# Altium schematic sheet S32K144EVB.SchDoc(S32K144EVB)
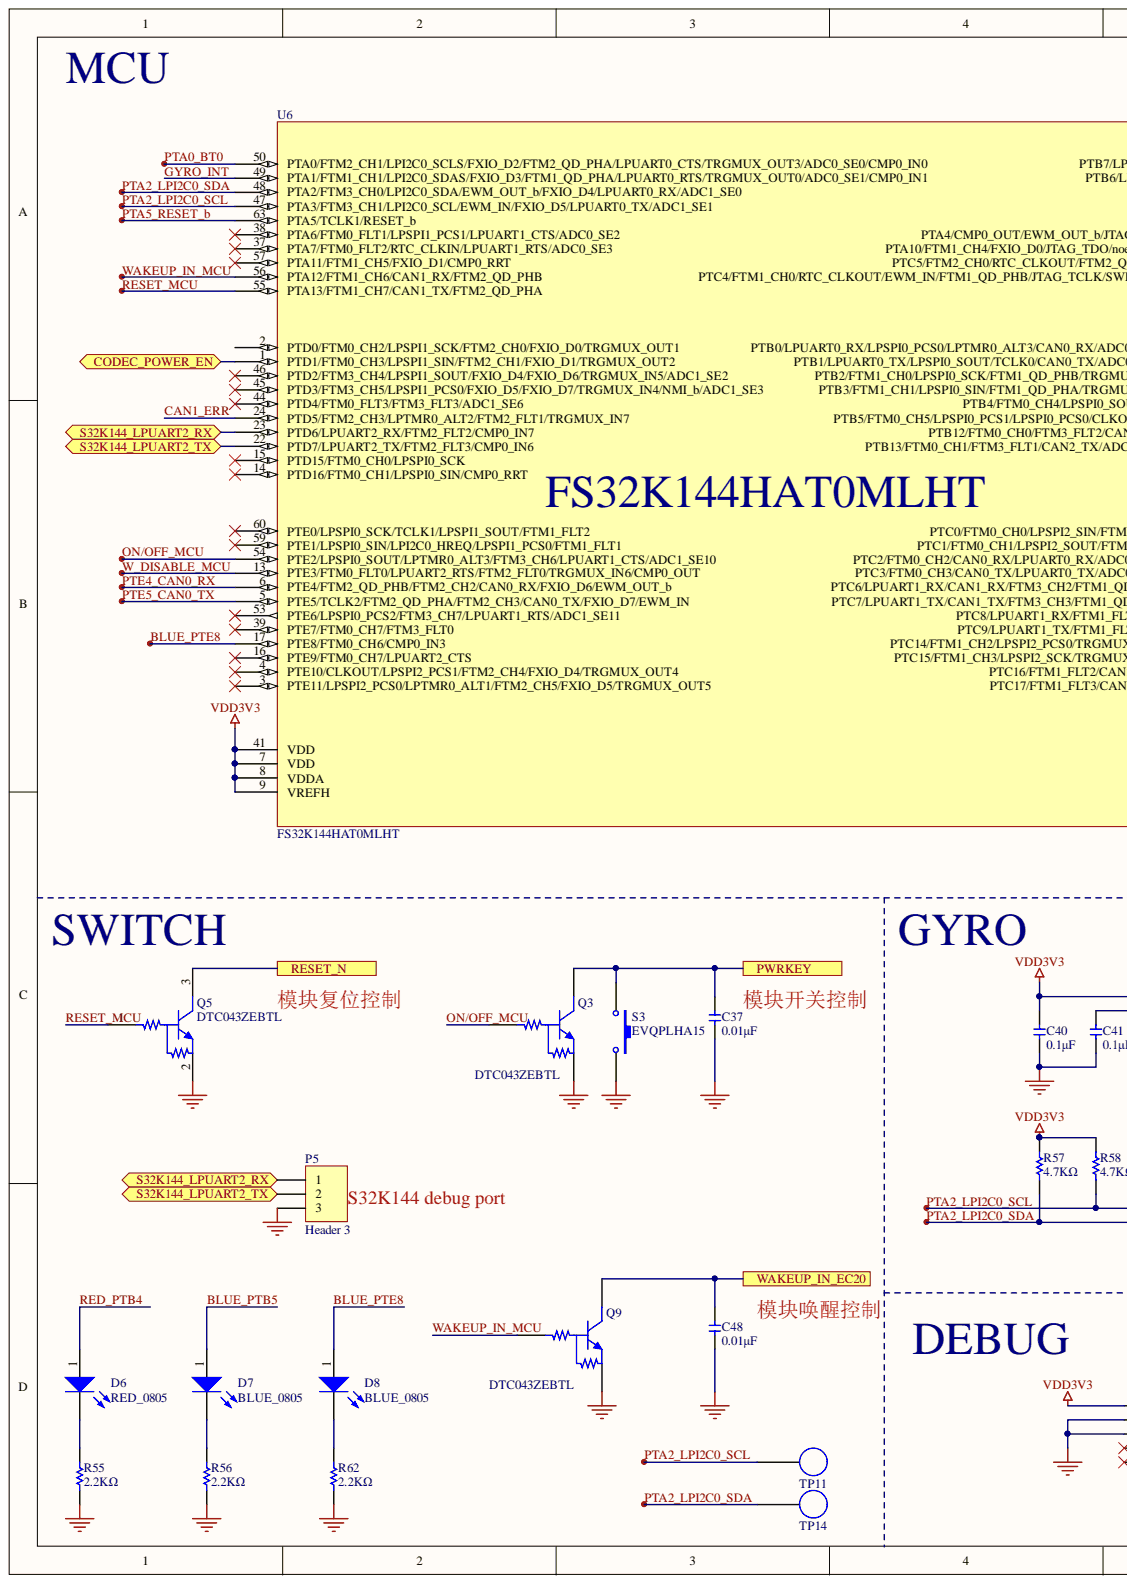
[diagram p0: S32K144EVB.SchDoc, left]
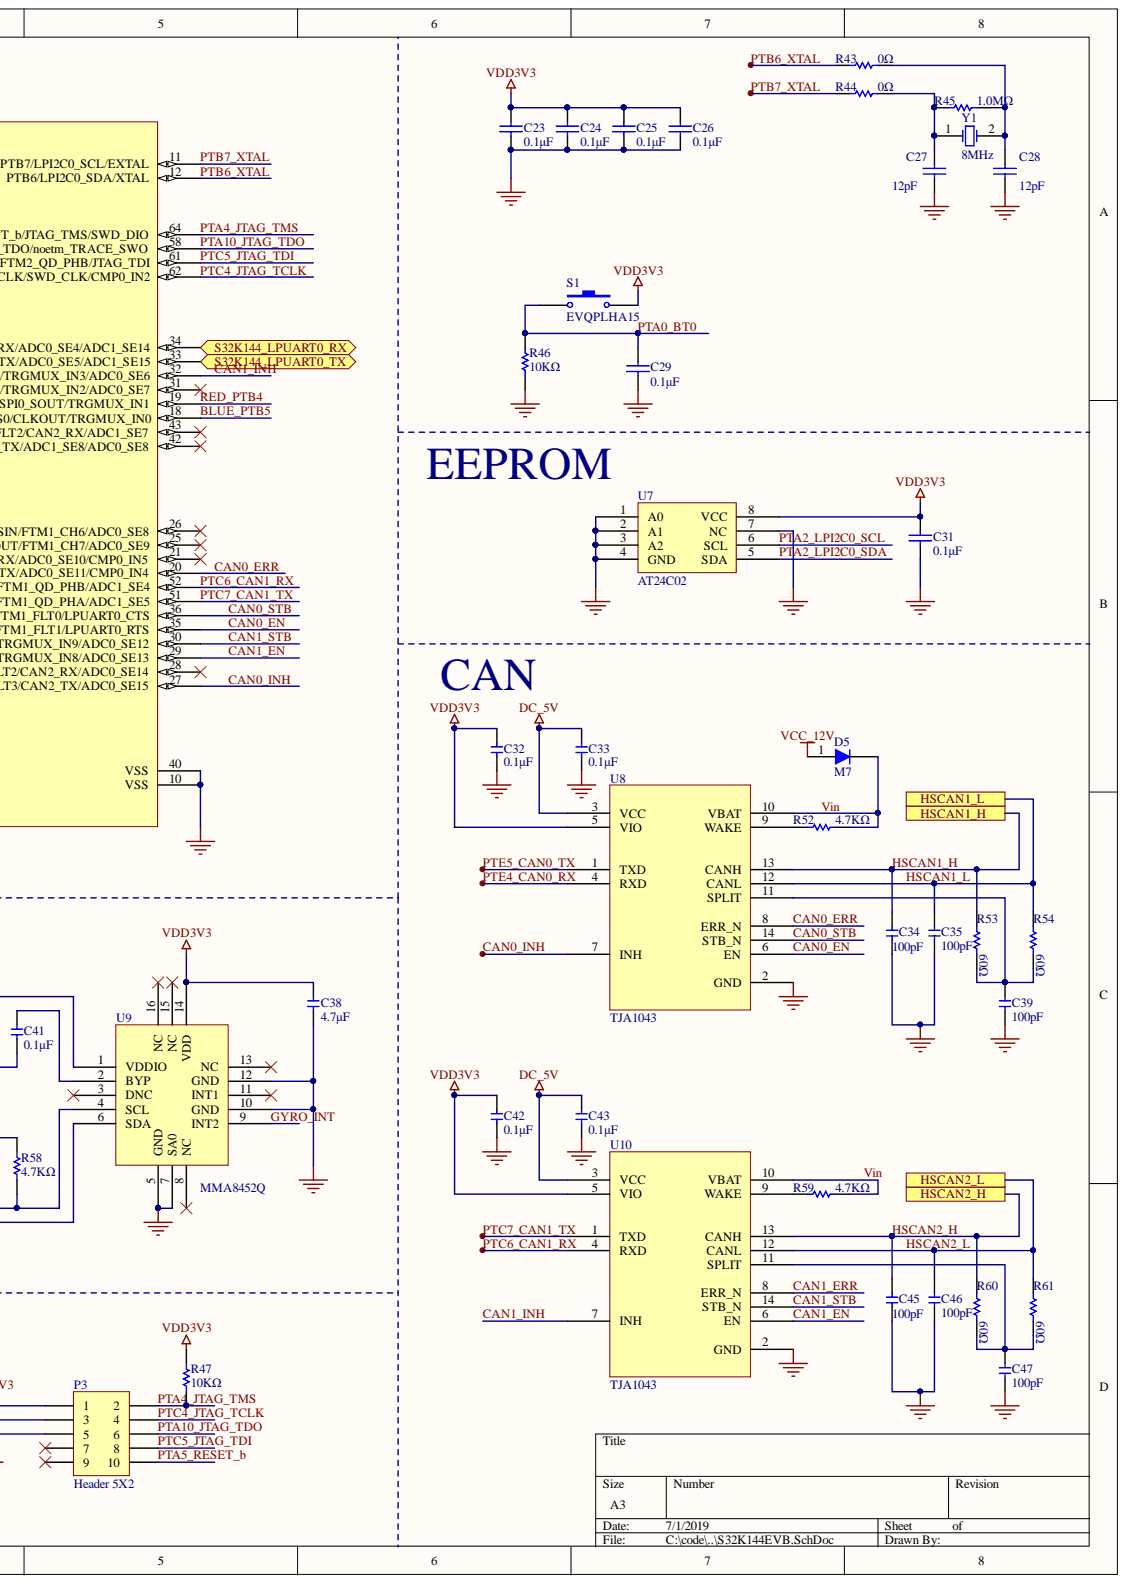
[diagram p1: S32K144EVB.SchDoc, right]

## Parts
C23  0.1μF  [C0402]
C24  0.1μF  [C0402]
C25  0.1μF  [C0402]
C26  0.1μF  [C0402]
C27  12pF  [C0402]
C28  12pF  [C0402]
C29  0.1μF  [C0402]
C31  0.1μF  [C0402]
C32  0.1μF  [C0402]
C33  0.1μF  [C0402]
C34  100pF  [C0402]
C35  100pF  [C0402]
C37  0.01μF  [C0402]
C38  4.7μF  [C0402]
C39  100pF  [C0402]
C40  0.1μF  [C0402]
C41  0.1μF  [C0402]
C42  0.1μF  [C0402]
C43  0.1μF  [C0402]
C45  100pF  [C0402]
C46  100pF  [C0402]
C47  100pF  [C0402]
C48  0.01μF  [C0402]
D5  M7  [SMA]
D6  RED_0805  [LED_0805]
D7  BLUE_0805  [LED_0805]
D8  BLUE_0805  [LED_0805]
P3  Header 5X2  [HDR5X2_127]
P5  Header 3  [HDR1X3]
Q3  DTC043ZEBTL  [SOT723]
Q5  DTC043ZEBTL  [SOT723]
Q9  DTC043ZEBTL  [SOT723]
R43  0Ω  [R0402]
R44  0Ω  [R0402]
R45  1.0MΩ  [R0402]
R46  10KΩ  [R0402]
R47  10KΩ  [R0402]
R52  4.7KΩ  [R0402]
R53  60Ω  [R0402]
R54  60Ω  [R0402]
R55  2.2KΩ  [R0402]
R56  2.2KΩ  [R0402]
R57  4.7KΩ  [R0402]
R58  4.7KΩ  [R0402]
R59  4.7KΩ  [R0402]
R60  60Ω  [R0402]
R61  60Ω  [R0402]
R62  2.2KΩ  [R0402]
S1  EVQPLHA15  [SW-DPST]
S3  EVQPLHA15  [SW-DPST]
TP11  TestPoint  [TP]  (value not drawn)
TP14  TestPoint  [TP]  (value not drawn)
U6  FS32K144HAT0MLHT  [LQFP-64]
U7  AT24C02  [SOIC-8_N]
U8  TJA1043  [SOIC-14 - 6MM]
U9  MMA8452Q  [QFN16]
U10  TJA1043  [SOIC-14 - 6MM]
Y1  8MHz  [XT5032]

## Ports
CODEC_POWER_EN, HSCAN1_H, HSCAN1_L, HSCAN2_H, HSCAN2_L, PWRKEY, RESET_N, S32K144_LPUART0_RX, S32K144_LPUART0_TX, S32K144_LPUART2_RX, S32K144_LPUART2_TX, WAKEUP_IN_EC20

## Nets
DC_5V: C33.2 C43.2 U8.3(VCC) U10.3(VCC)
GND: C23.1 C24.1 C25.1 C26.1 C27.1 C28.2 C29.1 C31.1 C32.1 C33.1 C34.1 C35.1 C37.1 C38.1 C39.1 C40.1 C41.1 C42.1 C43.1 C45.1 C46.1 C47.1 C48.1 P3.3 P3.5 P5.3 Q3.2 Q5.2 Q9.2 R46.2 R55.2 R56.2 R62.2 S3.2 U6.10(VSS) U6.40(VSS) U7.1(A0) U7.2(A1) U7.3(A2) U7.4(GND) U7.7(NC) U8.2(GND) U9.5(GND) U9.7(SA0) U9.10(GND) U9.12(GND) U10.2(GND)
VCC_12V: D5.1
VDD3V3: C23.2 C24.2 C25.2 C26.2 C31.2 C32.2 C38.2 C40.2 C42.2 P3.1 R47.1 R57.1 R58.1 S1.2 U6.7(VDD) U6.8(VDDA) U6.9(VREFH) U6.41(VDD) U7.8(VCC) U8.5(VIO) U9.1(VDDIO) U9.14(VDD) U10.5(VIO)
BLUE_PTB5: D7.1 U6.18(PTB5/FTM0_CH5/LPSPI0_PCS1/LPSPI0_PCS0/CLKOUT/TRGMUX_IN0)
BLUE_PTE8: D8.1 U6.17(PTE8/FTM0_CH6/CMP0_IN3)
CAN0_EN: U6.35(PTC9/LPUART1_TX/FTM1_FLT1/LPUART0_RTS) U8.6(EN)
CAN0_ERR: U6.20(PTC3/FTM0_CH3/CAN0_TX/LPUART0_TX/ADC0_SE11/CMP0_IN4) U8.8(ERR_N)
CAN0_INH: U6.27(PTC17/FTM1_FLT3/CAN2_TX/ADC0_SE15) U8.7(INH)
CAN0_STB: U6.36(PTC8/LPUART1_RX/FTM1_FLT0/LPUART0_CTS) U8.14(STB_N)
CAN1_EN: U6.29(PTC15/FTM1_CH3/LPSPI2_SCK/TRGMUX_IN8/ADC0_SE13) U10.6(EN)
CAN1_ERR: U6.24(PTD5/FTM2_CH3/LPTMR0_ALT2/FTM2_FLT1/TRGMUX_IN7) U10.8(ERR_N)
CAN1_INH: U6.32(PTB2/FTM1_CH0/LPSPI0_SCK/FTM1_QD_PHB/TRGMUX_IN3/ADC0_SE6) U10.7(INH)
CAN1_STB: U6.30(PTC14/FTM1_CH2/LPSPI2_PCS0/TRGMUX_IN9/ADC0_SE12) U10.14(STB_N)
CODEC_POWER_EN: U6.1(PTD1/FTM0_CH3/LPSPI1_SIN/FTM2_CH1/FXIO_D1/TRGMUX_OUT2) ; port CODEC_POWER_EN
GYRO_INT: U6.49(PTA1/FTM1_CH1/LPI2C0_SDAS/FXIO_D3/FTM1_QD_PHA/LPUART0_RTS/TRGMUX_OUT0/ADC0_SE1/CMP0_IN1) U9.9(INT2)
HSCAN1_H: C34.2 R53.2 U8.13(CANH) ; port HSCAN1_H
HSCAN1_L: C35.2 R54.2 U8.12(CANL) ; port HSCAN1_L
HSCAN2_H: C45.2 R60.2 U10.13(CANH) ; port HSCAN2_H
HSCAN2_L: C46.2 R61.2 U10.12(CANL) ; port HSCAN2_L
ON/OFF_MCU: Q3.1 U6.54(PTE2/LPSPI0_SOUT/LPTMR0_ALT3/FTM3_CH6/LPUART1_CTS/ADC1_SE10)
PTA0_BT0: C29.2 R46.1 S1.1 U6.50(PTA0/FTM2_CH1/LPI2C0_SCLS/FXIO_D2/FTM2_QD_PHA/LPUART0_CTS/TRGMUX_OUT3/ADC0_SE0/CMP0_IN0)
PTA2_LPI2C0_SCL: R58.2 TP11.1 U6.47(PTA3/FTM3_CH1/LPI2C0_SCL/EWM_IN/FXIO_D5/LPUART0_TX/ADC1_SE1) U7.6(SCL) U9.4(SCL)
PTA2_LPI2C0_SDA: R57.2 TP14.1 U6.48(PTA2/FTM3_CH0/LPI2C0_SDA/EWM_OUT_b/FXIO_D4/LPUART0_RX/ADC1_SE0) U7.5(SDA) U9.6(SDA)
PTA4_JTAG_TMS: P3.2 R47.2 U6.64(PTA4/CMP0_OUT/EWM_OUT_b/JTAG_TMS/SWD_DIO)
PTA5_RESET_b: P3.10 U6.63(PTA5/TCLK1/RESET_b)
PTA10_JTAG_TDO: P3.6 U6.58(PTA10/FTM1_CH4/FXIO_D0/JTAG_TDO/noetm_TRACE_SWO)
PTB6_XTAL: R43.2 U6.12(PTB6/LPI2C0_SDA/XTAL)
PTB7_XTAL: R44.2 U6.11(PTB7/LPI2C0_SCL/EXTAL)
PTC4_JTAG_TCLK: P3.4 U6.62(PTC4/FTM1_CH0/RTC_CLKOUT/EWM_IN/FTM1_QD_PHB/JTAG_TCLK/SWD_CLK/CMP0_IN2)
PTC5_JTAG_TDI: P3.8 U6.61(PTC5/FTM2_CH0/RTC_CLKOUT/FTM2_QD_PHB/JTAG_TDI)
PTC6_CAN1_RX: U6.52(PTC6/LPUART1_RX/CAN1_RX/FTM3_CH2/FTM1_QD_PHB/ADC1_SE4) U10.4(RXD)
PTC7_CAN1_TX: U6.51(PTC7/LPUART1_TX/CAN1_TX/FTM3_CH3/FTM1_QD_PHA/ADC1_SE5) U10.1(TXD)
PTE4_CAN0_RX: U6.6(PTE4/FTM2_QD_PHB/FTM2_CH2/CAN0_RX/FXIO_D6/EWM_OUT_b) U8.4(RXD)
PTE5_CAN0_TX: U6.5(PTE5/TCLK2/FTM2_QD_PHA/FTM2_CH3/CAN0_TX/FXIO_D7/EWM_IN) U8.1(TXD)
PWRKEY: C37.2 Q3.3 S3.1 ; port PWRKEY
RED_PTB4: D6.1 U6.19(PTB4/FTM0_CH4/LPSPI0_SOUT/TRGMUX_IN1)
RESET_MCU: Q5.1 U6.55(PTA13/FTM1_CH7/CAN1_TX/FTM2_QD_PHA)
RESET_N: Q5.3 ; port RESET_N
S32K144_LPUART0_RX: U6.34(PTB0/LPUART0_RX/LPSPI0_PCS0/LPTMR0_ALT3/CAN0_RX/ADC0_SE4/ADC1_SE14) ; port S32K144_LPUART0_RX
S32K144_LPUART0_TX: U6.33(PTB1/LPUART0_TX/LPSPI0_SOUT/TCLK0/CAN0_TX/ADC0_SE5/ADC1_SE15) ; port S32K144_LPUART0_TX
S32K144_LPUART2_RX: P5.1 U6.23(PTD6/LPUART2_RX/FTM2_FLT2/CMP0_IN7) ; port S32K144_LPUART2_RX
S32K144_LPUART2_TX: P5.2 U6.22(PTD7/LPUART2_TX/FTM2_FLT3/CMP0_IN6) ; port S32K144_LPUART2_TX
Vin: D5.2 R52.2 R59.2 U8.10(VBAT) U10.10(VBAT)
W_DISABLE_MCU: U6.13(PTE3/FTM0_FLT0/LPUART2_RTS/FTM2_FLT0/TRGMUX_IN6/CMP0_OUT)
WAKEUP_IN_EC20: C48.2 Q9.3 ; port WAKEUP_IN_EC20
WAKEUP_IN_MCU: Q9.1 U6.56(PTA12/FTM1_CH6/CAN1_RX/FTM2_QD_PHB)
NetC27_2: C27.2 R44.1 R45.2 Y1.1
NetC28_1: C28.1 R43.1 R45.1 Y1.2
NetC39_2: C39.2 R53.1 R54.1 U8.11(SPLIT)
NetC41_2: C41.2 U9.2(BYP)
NetC47_2: C47.2 R60.1 R61.1 U10.11(SPLIT)
NetD6_2: D6.2 R55.1
NetD7_2: D7.2 R56.1
NetD8_2: D8.2 R62.1
NetR52_1: R52.1 U8.9(WAKE)
NetR59_1: R59.1 U10.9(WAKE)

Not connected: P3 7 9; U6 2(PTD0/FTM0_CH2/LPSPI1_SCK/FTM2_CH0/FXIO_D0/TRGMUX_OUT1) 3(PTE11/LPSPI2_PCS0/LPTMR0_ALT1/FTM2_CH5/FXIO_D5/TRGMUX_OUT5) 4(PTE10/CLKOUT/LPSPI2_PCS1/FTM2_CH4/FXIO_D4/TRGMUX_OUT4) 14(PTD16/FTM0_CH1/LPSPI0_SIN/CMP0_RRT) 15(PTD15/FTM0_CH0/LPSPI0_SCK) 16(PTE9/FTM0_CH7/LPUART2_CTS) 21(PTC2/FTM0_CH2/CAN0_RX/LPUART0_RX/ADC0_SE10/CMP0_IN5) 25(PTC1/FTM0_CH1/LPSPI2_SOUT/FTM1_CH7/ADC0_SE9) 26(PTC0/FTM0_CH0/LPSPI2_SIN/FTM1_CH6/ADC0_SE8) 28(PTC16/FTM1_FLT2/CAN2_RX/ADC0_SE14) 31(PTB3/FTM1_CH1/LPSPI0_SIN/FTM1_QD_PHA/TRGMUX_IN2/ADC0_SE7) 37(PTA7/FTM0_FLT2/RTC_CLKIN/LPUART1_RTS/ADC0_SE3) 38(PTA6/FTM0_FLT1/LPSPI1_PCS1/LPUART1_CTS/ADC0_SE2) 39(PTE7/FTM0_CH7/FTM3_FLT0) 42(PTB13/FTM0_CH1/FTM3_FLT1/CAN2_TX/ADC1_SE8/ADC0_SE8) 43(PTB12/FTM0_CH0/FTM3_FLT2/CAN2_RX/ADC1_SE7) 44(PTD4/FTM0_FLT3/FTM3_FLT3/ADC1_SE6) 45(PTD3/FTM3_CH5/LPSPI1_PCS0/FXIO_D5/FXIO_D7/TRGMUX_IN4/NMI_b/ADC1_SE3) 46(PTD2/FTM3_CH4/LPSPI1_SOUT/FXIO_D4/FXIO_D6/TRGMUX_IN5/ADC1_SE2) 53(PTE6/LPSPI0_PCS2/FTM3_CH7/LPUART1_RTS/ADC1_SE11) 57(PTA11/FTM1_CH5/FXIO_D1/CMP0_RRT) 59(PTE1/LPSPI0_SIN/LPI2C0_HREQ/LPSPI1_PCS0/FTM1_FLT1) 60(PTE0/LPSPI0_SCK/TCLK1/LPSPI1_SOUT/FTM1_FLT2); U9 3(DNC) 8(NC) 11(INT1) 13(NC) 15(NC) 16(NC)

## Library data of the parts
C23-C29 C31 = Cap: Capacitor; Simulation: CAP; Signal Integrity: Cap; Published: 8-Jun-2000; PackageReference: RAD-0.3; PackageDescription: Radial Cap, Thru-Hole; 2 Leads; 0.3 in Pin Spacing; Library Name: Miscellaneous Devices.SchLib; LatestRevisionNote: Re-released for DXP Platform.; LatestRevisionDate: 17-Jul-2002; Component Kind: Standard; Publisher: Altium Limited
  C23-C26 C29 C31: Value: 0.1μF
  C27 C28: Value: 12pF
C32-C35 C37-C43 C45-C48 = Cap Semi: Capacitor (Semiconductor SIM Model); Simulation: CAP; Signal Integrity: Cap Semi; Published: 8-Jun-2000; PackageReference: C1206; PackageDescription: Chip Capacitor; Library Name: Miscellaneous Devices.SchLib; LatestRevisionNote: IPC-7351 Footprint Added.; LatestRevisionDate: 29-May-2009; Component Kind: Standard; Publisher: Altium Limited
  C32 C33 C40-C43: Value: 0.1μF
  C34 C35 C39 C45-C47: Value: 100pF
  C37 C48: Value: 0.01μF
  C38: Value: 4.7μF
D5 = Diode: Default Diode; Simulation: DIODE; Signal Integrity: Diode; Published: 8-Jun-2000; PackageVersion: Sep-1996; PackageReference: SMC; PackageDescription: DO-214-AB/SMC; 2 C-Bend Leads; Body 7.9 x 5.9 mm, inc. leads (LxW); Library Name: Miscellaneous Devices.SchLib; LatestRevisionNote: Re-released for DXP Platform.; LatestRevisionDate: 17-Jul-2002; Component Kind: Standard; Code_JEDEC: DO-214-AB; Publisher: Altium Limited
D6-D8 = LED0: Typical INFRARED GaAs LED; Simulation: LED0; Published: 8-Jun-2000; PackageReference: LED-0; PackageDescription: LED; 2 Leads; Library Name: Miscellaneous Devices.SchLib; LatestRevisionNote: Mech Layer 1 removed.; LatestRevisionDate: 08-Jul-2005; Component Kind: Standard; Publisher: Altium Limited
P3 = Header 5X2: Header, 5-Pin, Dual row; Signal Integrity: Connector; Library Name: Miscellaneous Connectors.IntLib; LatestRevisionNote: Re-released for DXP Platform.; LatestRevisionDate: 17-Jul-2002; Component Kind: Standard; Publisher: Altium Limited
P5 = Header 3: Header, 3-Pin; Signal Integrity: Connector; Library Name: Miscellaneous Connectors.IntLib; LatestRevisionNote: Re-released for DXP Platform.; LatestRevisionDate: 17-Jul-2002; Component Kind: Standard; Publisher: Altium Limited
Q3 Q5 Q9 = DTC043ZEBTL: NPN Darlington Bipolar Transistor; Simulation: NPN3; Signal Integrity: NPN; Published: 8-Jun-2000; PackageReference: TO-237-AA; PackageDescription: TO, Flat Index; 3 In-Line, Axial Leads; Body Dia. 4.8mm; Lead Dia. 0.56 mm (max); Library Name: Miscellaneous Devices.SchLib; LatestRevisionNote: Re-released for DXP Platform.; LatestRevisionDate: 17-Jul-2002; Component Kind: Standard; Code_JEDEC: TO-237-AA; Publisher: Altium Limited
R43-R47 R52-R62 = Res1: Resistor; Simulation: RESISTOR; Signal Integrity: Res; Published: 8-Jun-2000; PackageReference: AXIAL-0.3; PackageDescription: Axial Device, Thru-Hole; 2 Leads; 0.3 in Pin Spacing; Library Name: Miscellaneous Devices.SchLib; LatestRevisionNote: Re-released for DXP Platform.; LatestRevisionDate: 17-Jul-2002; Component Kind: Standard; Publisher: Altium Limited
  R43 R44: Value: 0Ω
  R45: Value: 1.0MΩ
  R46 R47: Value: 10KΩ
  R52 R57-R59: Value: 4.7KΩ
  R53 R54 R60 R61: Value: 60Ω
  R55 R56 R62: Value: 2.2KΩ
S1 S3 = SW-PB: Switch; Published: 24-Mar-1999; PackageReference: SPST-2; PackageDescription: Switch; 2 Leads; Library Name: Miscellaneous Devices.SchLib; LatestRevisionNote: Re-released for DXP Platform.; LatestRevisionDate: 17-Jul-2002; Component Kind: Standard; Publisher: Altium Limited
U6 = S32K144EVB: S32K14x series of devices are 32-bit general purpose automotive microcontrollers based  on the Arm Cortex-M4F core.; Library Name: Miscellaneous Devices.SchLib; Component Kind: Standard
U7 = AT24C02: The AT24C02 provides 2048 bits of serial  electrically erasable and programmable read-only memory (EEPROM) organized as  256 words of 8 bits each.; Library Name: Miscellaneous Devices.SchLib; Component Kind: Standard
U8 U10 = TJA1043: High-speed CAN transceiver; Library Name: Miscellaneous Devices.SchLib; Component Kind: Standard
U9 = MMA8452Q: 3-axis, 12-bit/8-bit  digital accelerometer; Library Name: Miscellaneous Devices.SchLib; Component Kind: Standard
Y1 = XTAL: Crystal Oscillator; Simulation: XTAL; Published: 8-Jun-2000; PackageReference: R38; PackageDescription: Crystal, Thru-Hole; 2 Leads; Body 3.1 x 8.2 mm (Dia.xH); Library Name: Miscellaneous Devices.SchLib; LatestRevisionNote: Re-released for DXP Platform.; LatestRevisionDate: 17-Jul-2002; Component Kind: Standard; Publisher: Altium Limited
TP11 TP14 = TestPoint: Library Name: Miscellaneous Devices.SchLib; Component Kind: Standard
